# Altium schematic sheet Camera.SchDoc(Camera)
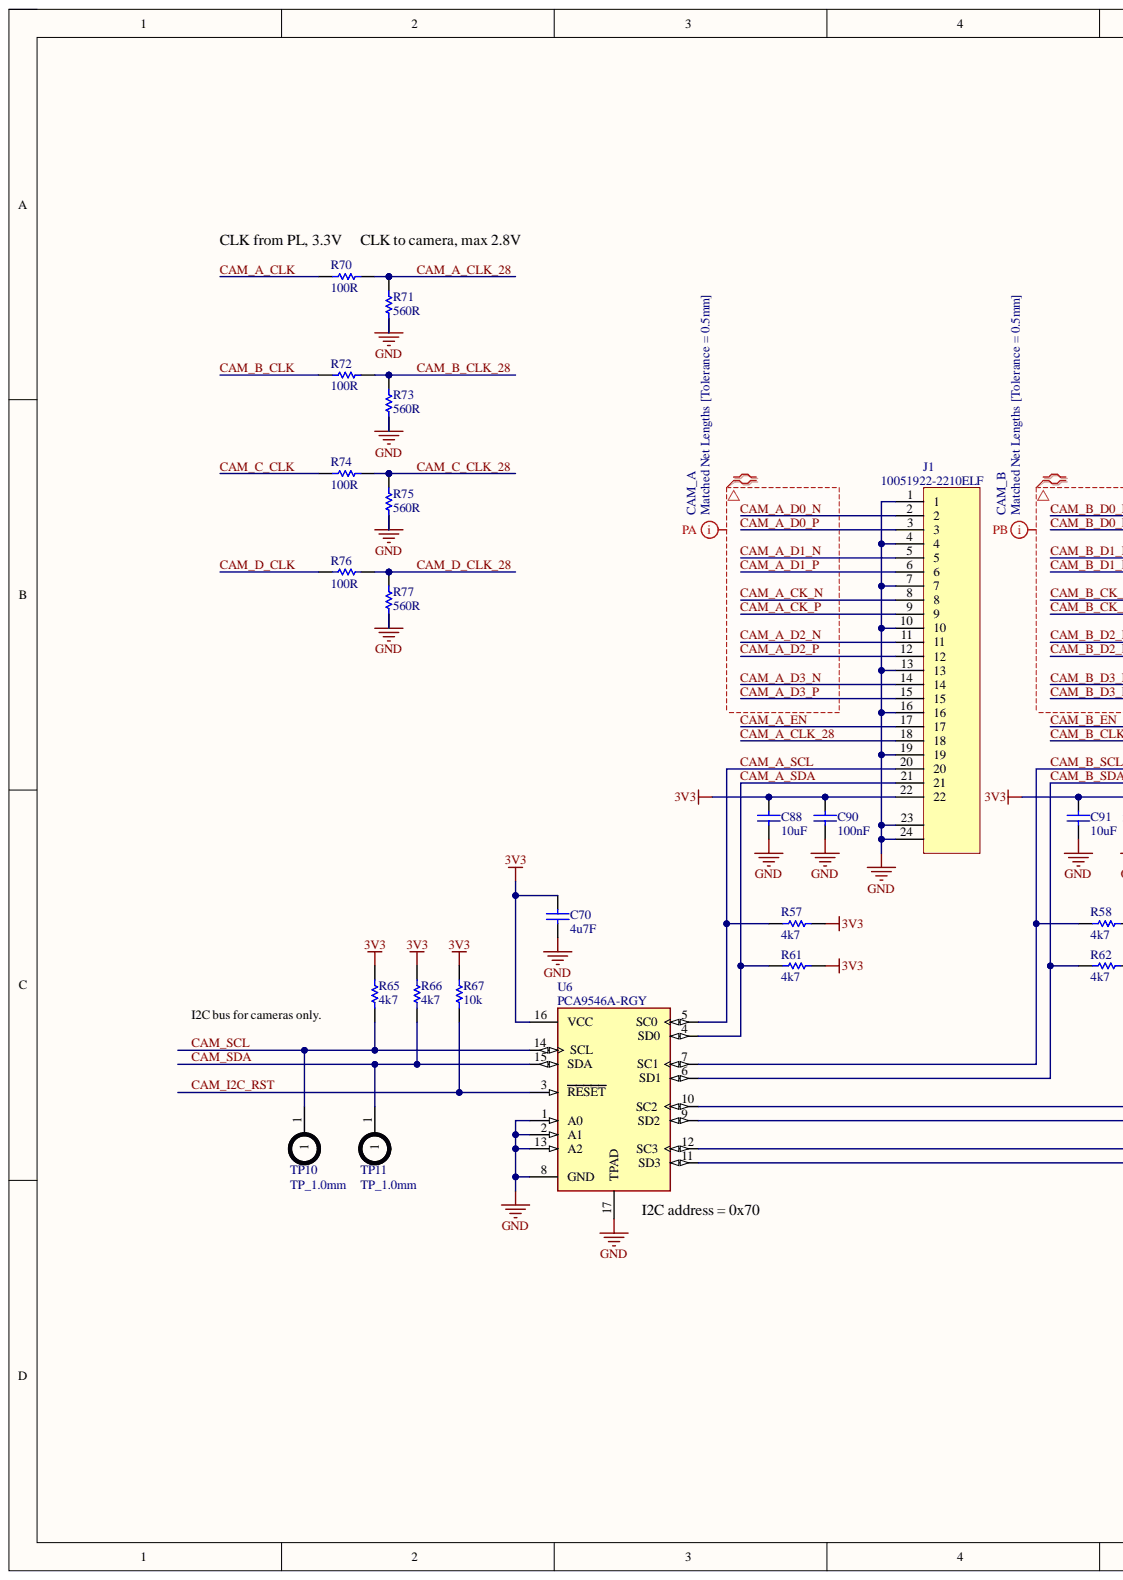
[diagram p0: Camera.SchDoc, left]
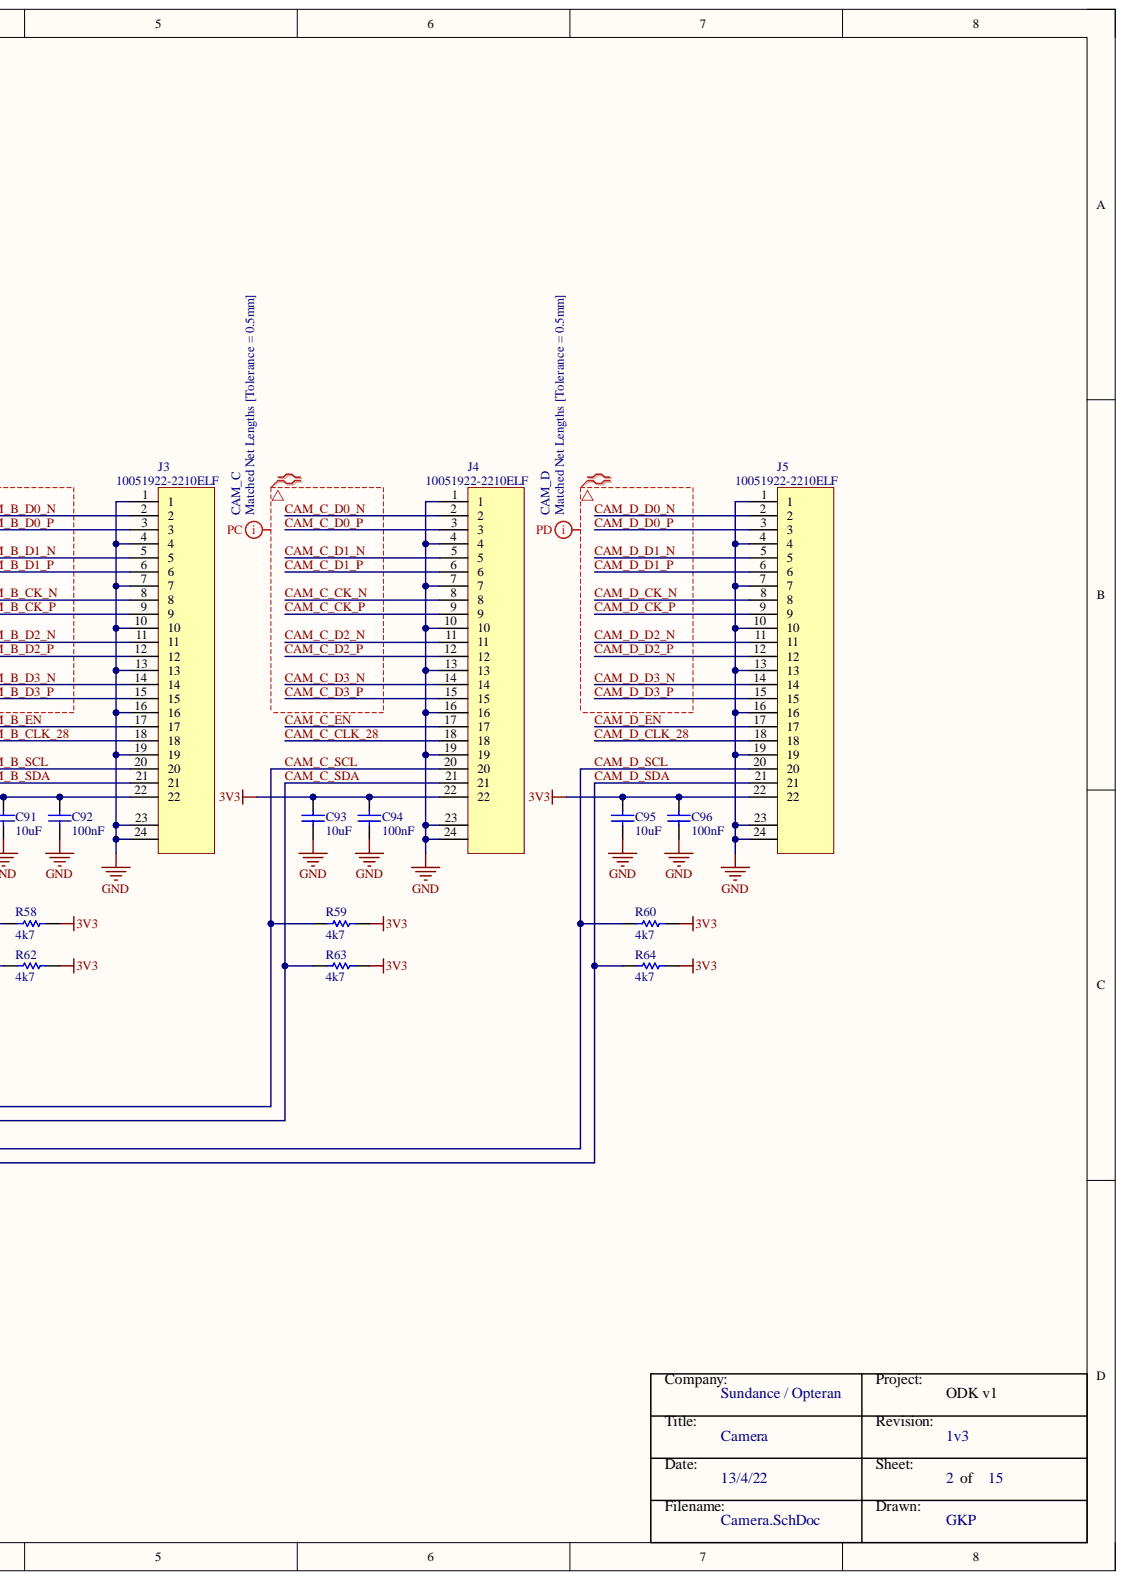
[diagram p1: Camera.SchDoc, right]

## Parts
C70  4u7F  [0201panERJ]
C88  10uF  [CAP0402]
C90  100nF  [0201panERJ]
C91  10uF  [CAP0402]
C92  100nF  [0201panERJ]
C93  10uF  [CAP0402]
C94  100nF  [0201panERJ]
C95  10uF  [CAP0402]
C96  100nF  [0201panERJ]
J1  10051922-2210ELF  [FCI 10051922]
J3  10051922-2210ELF  [FCI 10051922]
J4  10051922-2210ELF  [FCI 10051922]
J5  10051922-2210ELF  [FCI 10051922]
R57  4k7  [RES0201]
R58  4k7  [RES0201]
R59  4k7  [RES0201]
R60  4k7  [RES0201]
R61  4k7  [RES0201]
R62  4k7  [RES0201]
R63  4k7  [RES0201]
R64  4k7  [RES0201]
R65  4k7  [RES0201]
R66  4k7  [RES0201]
R67  10k  [RES0201]
R70  100R  [RES0402]
R71  560R  [RES0402]
R72  100R  [RES0402]
R73  560R  [RES0402]
R74  100R  [RES0402]
R75  560R  [RES0402]
R76  100R  [RES0402]
R77  560R  [RES0402]
TP10  TP_1.0mm  [TPR_1.0MM]  (no BOM)
TP11  TP_1.0mm  [TPR_1.0MM]  (no BOM)
U6  PCA9546A-RGY  [RGY16_2P55X2P05]

## Nets
3V3: C70.2 C88.2 C90.2 C91.2 C92.2 C93.2 C94.2 C95.2 C96.2 J1.22 J3.22 J4.22 J5.22 R57.1 R58.1 R59.1 R60.1 R61.1 R62.1 R63.1 R64.1 R65.2 R66.2 R67.2 U6.16(VCC)
GND: C70.1 C88.1 C90.1 C91.1 C92.1 C93.1 C94.1 C95.1 C96.1 J1.1 J1.4 J1.7 J1.10 J1.13 J1.16 J1.19 J1.23 J1.24 J3.1 J3.4 J3.7 J3.10 J3.13 J3.16 J3.19 J3.23 J3.24 J4.1 J4.4 J4.7 J4.10 J4.13 J4.16 J4.19 J4.23 J4.24 J5.1 J5.4 J5.7 J5.10 J5.13 J5.16 J5.19 J5.23 J5.24 R71.1 R73.1 R75.1 R77.1 U6.1(A0) U6.2(A1) U6.8(GND) U6.13(A2) U6.17(TPAD)
CAM_A_CK_N: J1.8
CAM_A_CK_P: J1.9
CAM_A_CLK: R70.1
CAM_A_CLK_28: J1.18 R70.2 R71.2
CAM_A_D0_N: J1.2
CAM_A_D0_P: J1.3
CAM_A_D1_N: J1.5
CAM_A_D1_P: J1.6
CAM_A_D2_N: J1.11
CAM_A_D2_P: J1.12
CAM_A_D3_N: J1.14
CAM_A_D3_P: J1.15
CAM_A_EN: J1.17
CAM_A_SCL: J1.20 R57.2 U6.5(SC0)
CAM_A_SDA: J1.21 R61.2 U6.4(SD0)
CAM_B_CK_N: J3.8
CAM_B_CK_P: J3.9
CAM_B_CLK: R72.1
CAM_B_CLK_28: J3.18 R72.2 R73.2
CAM_B_D0_N: J3.2
CAM_B_D0_P: J3.3
CAM_B_D1_N: J3.5
CAM_B_D1_P: J3.6
CAM_B_D2_N: J3.11
CAM_B_D2_P: J3.12
CAM_B_D3_N: J3.14
CAM_B_D3_P: J3.15
CAM_B_EN: J3.17
CAM_B_SCL: J3.20 R58.2 U6.7(SC1)
CAM_B_SDA: J3.21 R62.2 U6.6(SD1)
CAM_C_CK_N: J4.8
CAM_C_CK_P: J4.9
CAM_C_CLK: R74.1
CAM_C_CLK_28: J4.18 R74.2 R75.2
CAM_C_D0_N: J4.2
CAM_C_D0_P: J4.3
CAM_C_D1_N: J4.5
CAM_C_D1_P: J4.6
CAM_C_D2_N: J4.11
CAM_C_D2_P: J4.12
CAM_C_D3_N: J4.14
CAM_C_D3_P: J4.15
CAM_C_EN: J4.17
CAM_C_SCL: J4.20 R59.2 U6.10(SC2)
CAM_C_SDA: J4.21 R63.2 U6.9(SD2)
CAM_D_CK_N: J5.8
CAM_D_CK_P: J5.9
CAM_D_CLK: R76.1
CAM_D_CLK_28: J5.18 R76.2 R77.2
CAM_D_D0_N: J5.2
CAM_D_D0_P: J5.3
CAM_D_D1_N: J5.5
CAM_D_D1_P: J5.6
CAM_D_D2_N: J5.11
CAM_D_D2_P: J5.12
CAM_D_D3_N: J5.14
CAM_D_D3_P: J5.15
CAM_D_EN: J5.17
CAM_D_SCL: J5.20 R60.2 U6.12(SC3)
CAM_D_SDA: J5.21 R64.2 U6.11(SD3)
CAM_I2C_RST: R67.1 U6.3(RESET)
CAM_SCL: R65.1 TP10.1 U6.14(SCL)
CAM_SDA: R66.1 TP11.1 U6.15(SDA)

## Library data of the parts
C70 = Cap0201: 4u7F 0201 6V3 X5R; Case-EIA: 0402; Component Kind: Standard; Library Name: Schematic Components.SchLib; Max Thickness: 0.6 mm; Technology: SMT
C88 C91 C93 C95 = Cap0402: 10uF 0402 10V X5R; Case-EIA: 0402; Component Kind: Standard; Library Name: Schematic Components.SchLib; Max Thickness: 0.6 mm; Technology: SMT
C90 C92 C94 C96 = Cap0201: 100nF 0201 16V X5R; Case-EIA: 0402; Component Kind: Standard; Library Name: Schematic Components.SchLib; Max Thickness: 0.6 mm; Technology: SMT
J1 J3-J5 = FPC 10051922-2210ELF: 22 Position FPC Connector Contacts, Bottom 0.020" (0.50mm) Surface Mount, Right Angle; Manufacturer 1: Amphenol ICC (FCI); Manufacturer Part Number 1: 609-10051922-2210ELFTR-ND; Component Kind: Standard; Library Name: Schematic Components.SchLib; Supplier 1: Digi-Key; Supplier Part Number 1: 609-10051922-2210ELFTR-ND
R57-R66 = Res0201: 4k7 0201 1/16W 1%; Value: ?k; Component Kind: Standard; Library Name: Schematic Components.SchLib
R67 = Res0201: 10k 0201 1/16W 1%; Value: ?k; Component Kind: Standard; Library Name: Schematic Components.SchLib
R70 R72 R74 R76 = Res0402: 100R 0402 1/16W 1%; Value: ?k; Component Kind: Standard; Library Name: Schematic Components.SchLib
R71 R73 R75 R77 = Res0402: 560R 0402 1/16W 1%; Value: ?k; Component Kind: Standard; Library Name: Schematic Components.SchLib
TP10 TP11 = TP_1.0mm: Test pad 1.0mm; Library Name: Connectors pads.SchLib
U6 = PCA9546A-RGY: Translating Switch Interface 16-VQFN (4x3.5); Manufacturer 1: Texas Instruments; Manufacturer Part Number 1: PCA9546ARGYR; Component Kind: Standard; Library Name: Schematic Components.SchLib; Supplier 1: Digi-Key; Supplier Part Number 1: 296-20969-2-ND
